# Altium schematic sheet Side.SchDoc(Side)
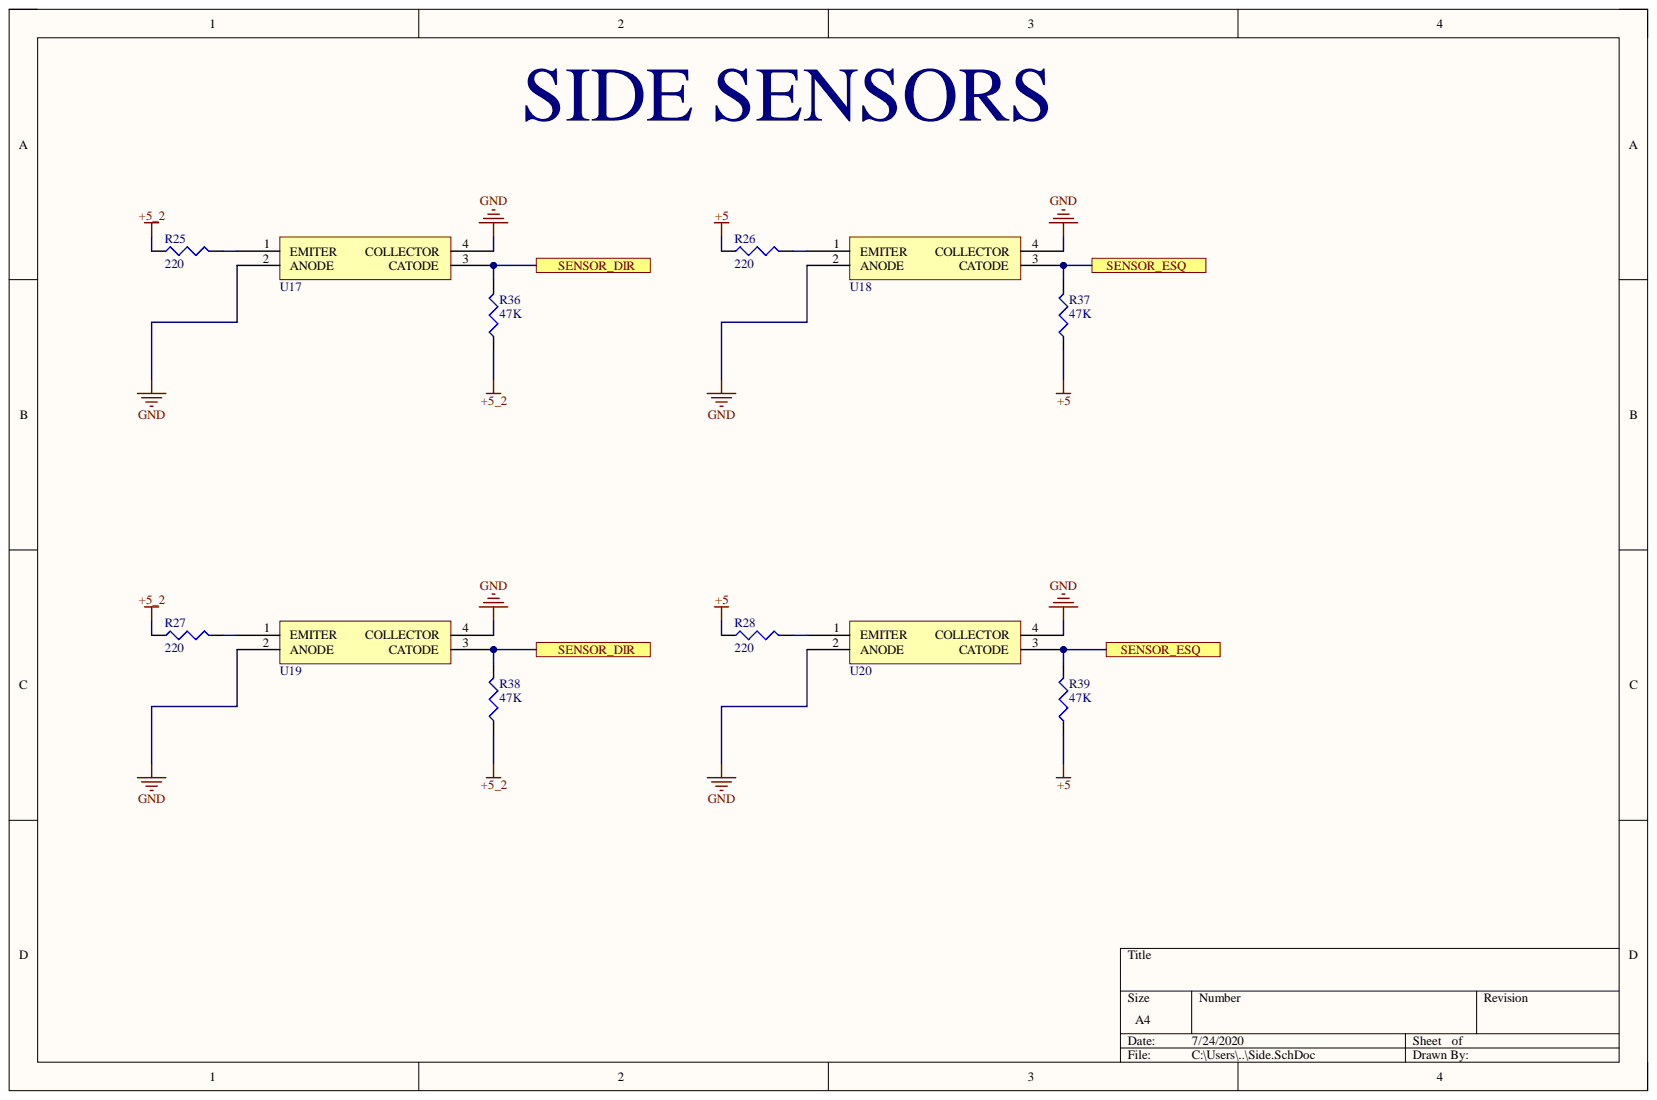
[diagram p0: Side.SchDoc]

## Parts
R25  220  [6-0805_M]
R26  220  [6-0805_M]
R27  220  [6-0805_M]
R28  220  [6-0805_M]
R36  47K  [6-0805_M]
R37  47K  [6-0805_M]
R38  47K  [6-0805_M]
R39  47K  [6-0805_M]
U17  Optical Switches  [QRE1113GR]  (value not drawn)
U18  Optical Switches  [QRE1113GR]  (value not drawn)
U19  Optical Switches  [QRE1113GR]  (value not drawn)
U20  Optical Switches  [QRE1113GR]  (value not drawn)

## Ports
SENSOR_DIR, SENSOR_ESQ

## Nets
+5: R26.1 R28.1 R37.1 R39.1
+5_2: R25.1 R27.1 R36.1 R38.1
GND: U17.2(ANODE) U17.4(COLLECTOR) U18.2(ANODE) U18.4(COLLECTOR) U19.2(ANODE) U19.4(COLLECTOR) U20.2(ANODE) U20.4(COLLECTOR)
SENSOR_DIR: R36.2 R38.2 U17.3(CATODE) U19.3(CATODE) ; port SENSOR_DIR
SENSOR_ESQ: R37.2 R39.2 U18.3(CATODE) U20.3(CATODE) ; port SENSOR_ESQ
NetR25_2: R25.2 U17.1(EMITER)
NetR26_2: R26.2 U18.1(EMITER)
NetR27_2: R27.2 U19.1(EMITER)
NetR28_2: R28.2 U20.1(EMITER)

## Library data of the parts
R25-R28 = Resistor 220 0805: RES SMD 220 OHM 1% 1/8W 0805; Manufacturer: Vishay Semiconductors; Manufacturer Part Number: CRCW0805220RFKEAC; Power Rating: 125mW; Resistance: 220R; Temperature Coefficient: 100ppm/°C; Case/Package: SMD/SMT; Category: Thick Film Resistors - SMD; Component Kind: Standard; Composition: Thick Film; Contact Plating: Tin; Lead Free: Lead Free; Length: 2mm; Max Operating Temperature: 155°C; Min Operating Temperature: -55°C; Mount: Surface Mount; Pricing 1: 1=0.1, 10=0.052, 100=0.02, 500=0.012, 1000=0.006, 5000=0.005 (USD); Stock 1: 36802; Supplier 1: Mouser; Supplier Part Number 1: 71-CRCW0805220RFKEAC; Termination: Wraparound; Tolerance: 1%; Library Name: BiricuticoBonito.SchLib
R36-R39 = Resistor 47K 0805: RES SMD 47K OHM 1% 1/8W 0805; Manufacturer: Vishay; Manufacturer Part Number: CRCW080547K0FKEA; Max Power Dissipation: 125mW; Resistance: 47kR; Temperature Coefficient: 100ppm/°C; Voltage Rating: 150V; Case Code (Imperial): 0805; Case Code (Metric): 2012; Case/Package: 0805; Category: Thick Film Resistors - SMD; Component Kind: Standard; ComponentLink1Description: https://www.alliedelec.com/m/d/0c934d6939ccefa68dbe0b96d821c8cf.pdf?src-supplier=Allied+Electronics; ComponentLink1URL: https://www.alliedelec.com/m/d/0c934d6939ccefa68dbe0b96d821c8cf.pdf?src-supplier=Allied+Electronics; ComponentLink2Description: http://www.vishay.com/docs/20035/dcrcwe3.pdf?src-supplier=Digi-Key; ComponentLink2URL: http://www.vishay.com/docs/20035/dcrcwe3.pdf?src-supplier=Digi-Key; ComponentLink3Description: http://www.vishay.com/docs/20035/dcrcwe3.pdf?src-supplier=Future+Electronics; ComponentLink3URL: http://www.vishay.com/docs/20035/dcrcwe3.pdf?src-supplier=Future+Electronics; ComponentLink4Description: https://www.distrelec.biz/Web/Downloads/a_/en/flD-CRCW-e3_data_en.pdf?mime=application%2Fpdf&src-supplier=Distrelec; ComponentLink4URL: https://www.distrelec.biz/Web/Downloads/a_/en/flD-CRCW-e3_data_en.pdf?mime=application%2Fpdf&src-supplier=Distrelec; ComponentLink5Description: http://www.farnell.com/datasheets/2310790.pdf?src-supplier=Element14; ComponentLink5URL: http://www.farnell.com/datasheets/2310790.pdf?src-supplier=Element14; ComponentLink6Description: http://www.vishay.com/docs/20035/dcrcwe3.pdf?src-supplier=Avnet; ComponentLink6URL: http://www.vishay.com/docs/20035/dcrcwe3.pdf?src-supplier=Avnet; ComponentLink7Description: http://uk.rs-online.com/webdocs/0d98/0900766b80d98b26.pdf?src-supplier=RSComponents; ComponentLink7URL: http://uk.rs-online.com/webdocs/0d98/0900766b80d98b26.pdf?src-supplier=RSComponents; ComponentLink8Description: http://www.vishay.com/docs/20035/dcrcwe3.pdf?src-supplier=Avnet+Europe; ComponentLink8URL: http://www.vishay.com/docs/20035/dcrcwe3.pdf?src-supplier=Avnet+Europe; ComponentLink9Description: http://www.farnell.com/datasheets/2046716.pdf?src-supplier=Element14; ComponentLink9URL: http://www.farnell.com/datasheets/2046716.pdf?src-supplier=Element14; Composition: Thick Film; Contact Plating: Tin; Depth: 1.25mm; Features: Automotive AEC-Q200; Height: 0.45mm; Lead Free: Lead Free; Length: 2mm; Max Operating Temperature: 155°C; Military Standard: Not; Min Operating Temperature: -55°C; Number of Terminations: 2; Package Quantity: 10; Packaging: Tape and Reel; Power Rating: 125mW; Pricing 1: 1=0.1, 10=0.066, 50=0.066, 100=0.047, 1000=0.027, 5000=0.019, 10000=0.015, 25000=0.013, 50000=0.011, 100000=0.01 (USD); Radiation Hardening: No; REACH SVHC: Unknown; Stock 1: 21962; Supplier 1: Mouser; Supplier Part Number 1: 71-CRCW0805-47K-E3; Termination: SMD/SMT; Tolerance: 1%; Voltage Rating (DC): 150V; Weight: 0.000194oz; Width: 1.25mm; Working Voltage: 150V; Library Name: BiricuticoBonito.SchLib
U17-U20 = Optical Switches: SENSOR OPTO TRANS REFL SMD PHOTO; Manufacturer: ON Semiconductor / Fairchild; Collector Emitter Breakdown Voltage: 30V; Fall Time: 20us; Forward Current: 50mA; Forward Voltage: 1.2V; Max Collector Current: 20mA; Max Power Dissipation: 75mW; Number of Channels: 1; Operating Supply Voltage: 1.7V; Output Type: Phototransistor; Reverse Breakdown Voltage: 5V; Sensing Distance: 0.197inch; Wavelength: 940nm; Case/Package: SMD/SMT; Category: Optical Switches, Reflective, Phototransistor Output; Collector Emitter Voltage (VCEO): 30V; Component Kind: Standard; ComponentLink1Description: http://www.farnell.com/datasheets/1725706.pdf?src-supplier=Element14; ComponentLink1URL: http://www.farnell.com/datasheets/1725706.pdf?src-supplier=Element14; ComponentLink2Description: https://www.verical.com/server-webapp/html/getDatasheet?partID=146181&src-supplier=Verical; ComponentLink2URL: https://www.verical.com/server-webapp/html/getDatasheet?partID=146181&src-supplier=Verical; ComponentLink3Description: https://www.fairchildsemi.com/datasheets/QR/QRE1113.pdf?src-supplier=Avnet; ComponentLink3URL: https://www.fairchildsemi.com/datasheets/QR/QRE1113.pdf?src-supplier=Avnet; ComponentLink4Description: http://www.fairchildsemi.com/ds/QR/QRE1113.pdf?src-supplier=Avnet+Europe; ComponentLink4URL: http://www.fairchildsemi.com/ds/QR/QRE1113.pdf?src-supplier=Avnet+Europe; ComponentLink5Description: https://www.fairchildsemi.com/datasheets/QR/QRE1113.pdf?src-supplier=Digi-Key; ComponentLink5URL: https://www.fairchildsemi.com/datasheets/QR/QRE1113.pdf?src-supplier=Digi-Key; Contact Plating: Tin,Matte; Height: 1.7mm; Input Current: 50mA; Lead Free: Lead Free; Length: 3.6mm; Manufacturer Part Number: QRE1113GR; Max Breakdown Voltage: 30V; Max Operating Temperature: 85°C; Min Operating Temperature: -40°C; Mount: Surface Mount; Number of Elements: 1; Number of Pins: 4; Package Quantity: 1000; Packaging: Tape and Reel; Power Dissipation: 75mW; Pricing 1: 1=0.86, 10=0.713, 50=0.713, 100=0.46, 1000=0.368, 10000=0.3 (USD); Radiation Hardening: No; REACH SVHC: No SVHC; Response Time: 20us; Reverse Voltage (DC): 5V; Rise Time: 20us; Stock 1: 10425; Supplier 1: Mouser; Supplier Part Number 1: 512-QRE1113GR; Weight: 0.078g; Width: 2.9mm; Library Name: BiricuticoBonito.SchLib
